# Altium schematic sheet ZYNQ_Core.SchDoc(ZYNQ_Core)
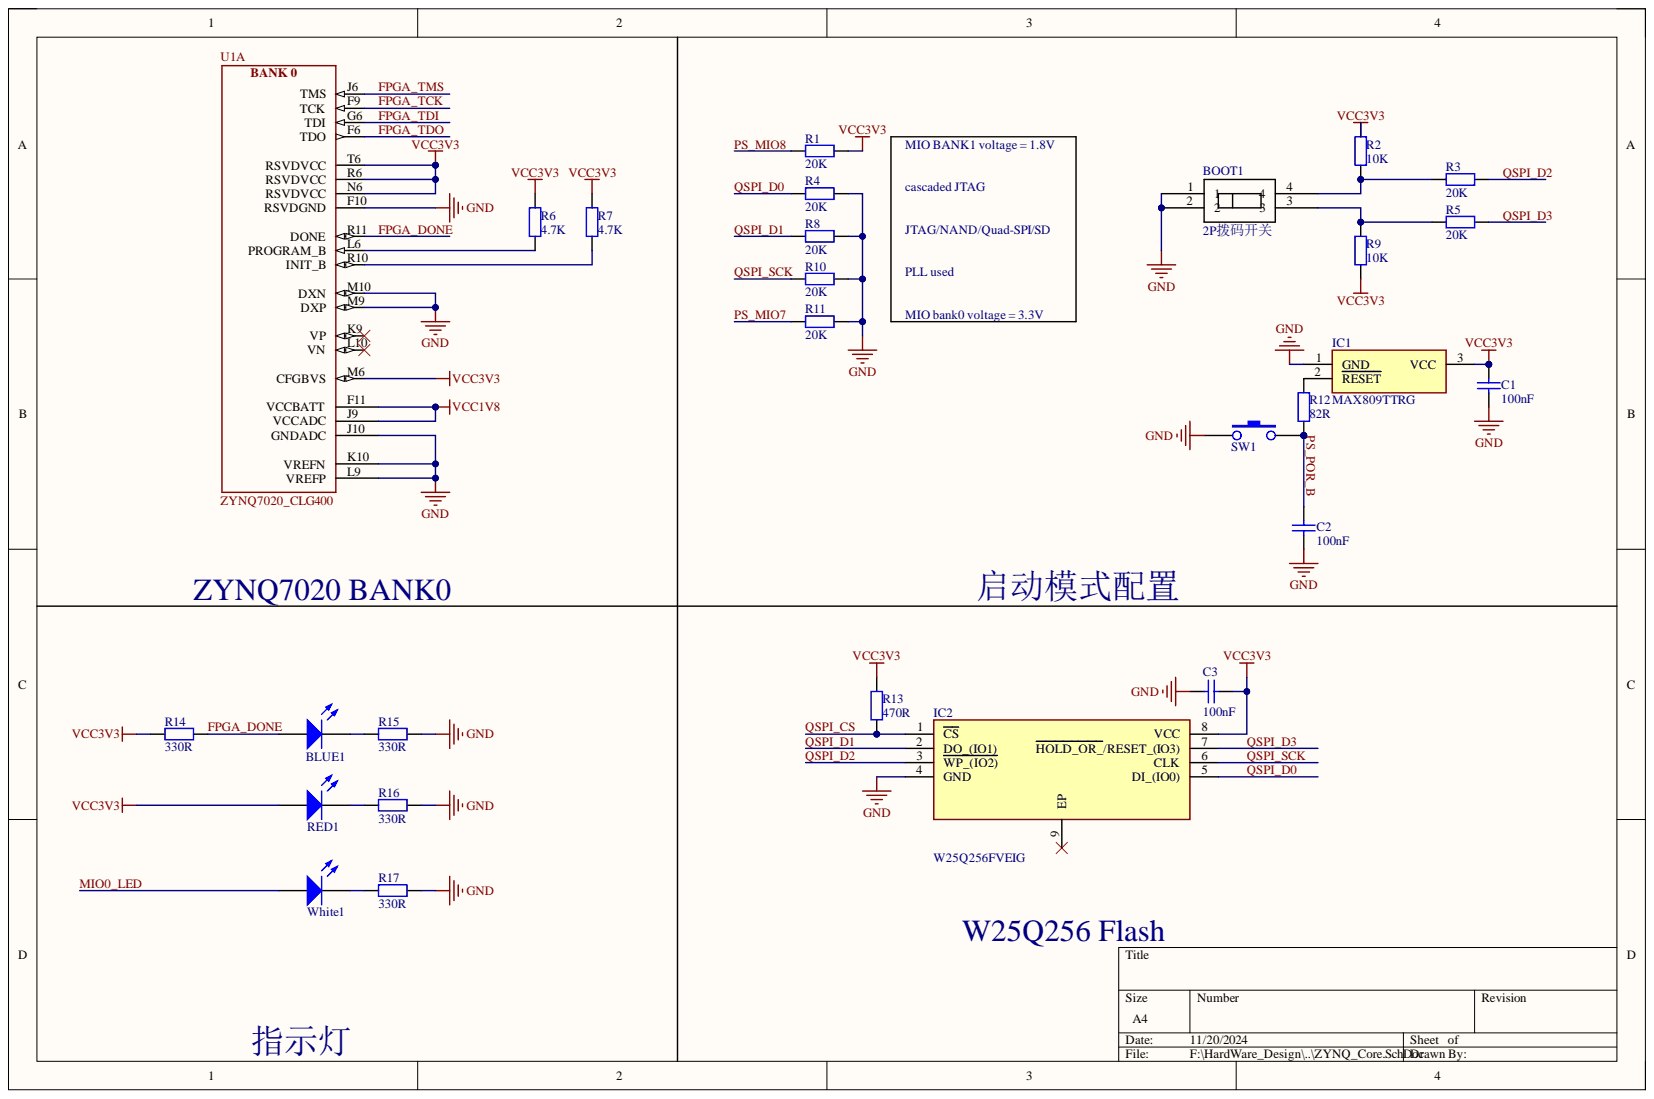
[diagram p0: ZYNQ_Core.SchDoc]

## Parts
BLUE1  LED  [LED 0402 B]  (value not drawn)
BOOT1  2P拨码开关  [CSW1.27 SMD-2P]
C1  100nF  [C 0402_L]
C2  100nF  [C 0402_L]
C3  100nF  [C 0402_L]
IC1  MAX809TTRG  [SOT96P237X111-3N]
IC2  W25Q256FVEIG  [SON127P800X600X80-9N-D]
R1  20K  [R 0402_L]
R2  10K  [R 0402_L]
R3  20K  [R 0402_L]
R4  20K  [R 0402_L]
R5  20K  [R 0402_L]
R6  4.7K  [R 0402_L]
R7  4.7K  [R 0402_L]
R8  20K  [R 0402_L]
R9  10K  [R 0402_L]
R10  20K  [R 0402_L]
R11  20K  [R 0402_L]
R12  82R  [R 0402_L]
R13  470R  [R 0402_L]
R14  330R  [R 0402_L]
R15  330R  [R 0402_L]
R16  330R  [R 0402_L]
R17  330R  [R 0402_L]
RED1  LED  [LED 0402 R]  (value not drawn)
SW1  按键  [SWT3*4]  (value not drawn)
U1  ZYNQ7020_CLG400  [BGA400C80P20X20_1700X1700X160]  (part A)
White1  LED  [LED 0402 G]  (value not drawn)

## Nets
GND: BOOT1.1 BOOT1.2 C1.1 C2.1 C3.2 IC1.1 IC2.4(GND) R4.2 R8.2 R10.2 R11.2 R15.1 R16.1 R17.1 SW1.1 U1.F10(RSVDGND) U1.J10(GNDADC) U1.K10(VREFN) U1.L9(VREFP) U1.M9(DXP) U1.M10(DXN)
VCC1V8: U1.F11(VCCBATT) U1.J9(VCCADC)
VCC3V3: C1.2 C3.1 IC1.3 IC2.8(VCC) R1.2 R2.2 R6.2 R7.2 R9.1 R13.1 R14.1 RED1.1 U1.M6(CFGBVS) U1.N6(RSVDVCC) U1.R6(RSVDVCC) U1.T6(RSVDVCC)
FPGA_DONE: BLUE1.1 R14.2 U1.R11(DONE)
FPGA_TCK: U1.F9(TCK)
FPGA_TDI: U1.G6(TDI)
FPGA_TDO: U1.F6(TDO)
FPGA_TMS: U1.J6(TMS)
MIO0_LED: White1.1
PS_MIO7: R11.1
PS_MIO8: R1.1
PS_POR_B: C2.2 R12.2 SW1.2
QSPI_CS: IC2.1(CS) R13.2
QSPI_D0: IC2.5(DI_(IO0)) R4.1
QSPI_D1: IC2.2(DO_(IO1)) R8.1
QSPI_D2: IC2.3(WP_(IO2)) R3.1
QSPI_D3: IC2.7(HOLD_OR_/RESET_(IO3)) R5.1
QSPI_SCK: IC2.6(CLK) R10.1
NetBLUE1_2: BLUE1.2 R15.2
NetBOOT1_3: BOOT1.3 R5.2 R9.2
NetBOOT1_4: BOOT1.4 R2.1 R3.2
NetIC1_2: IC1.2 R12.1
NetR6_1: R6.1 U1.L6(PROGRAM_B)
NetR7_1: R7.1 U1.R10(INIT_B)
NetR16_2: R16.2 RED1.2
NetR17_2: R17.2 White1.2

Not connected: IC2 9(EP); U1 K9(VP) L10(VN)

## Library data of the parts
C1-C3 = Cap1: Component Kind: Standard; value: 100nF; Library Name: 零散元件库2.SCHLIB
IC1 = MAX809TTRG: Integrated Circuit; Manufacturer_Name: onsemi; Arrow Part Number: MAX809TTRG; Arrow Price/Stock: https://www.arrow.com/en/products/max809ttrg/on-semiconductor; Component Kind: Standard; Datasheet Link: http://www.onsemi.com/pub/Collateral/MAX809S-D.PDF; Height: 1.11mm; Library Name: SamacSys.SchLib; Manufacturer_Part_Number: MAX809TTRG; Mouser Part Number: 863-MAX809TTRG; Mouser Price/Stock: https://www.mouser.co.uk/ProductDetail/onsemi/MAX809TTRG?qs=3JMERSakebpLWYPNGFPMSQ%3D%3D
IC2 = W25Q256FVEIG: Integrated Circuit; Manufacturer_Name: Winbond; Arrow Part Number: W25Q256FVEIG; Arrow Price/Stock: https://www.arrow.com/en/products/w25q256fveig/winbond-electronics; Component Kind: Standard; Datasheet Link: https://www.winbond.com/resource-files/w25q256fv%20revi%2002262016%20kms.pdf; Height: 0.8mm; Library Name: SamacSys.SchLib; Manufacturer_Part_Number: W25Q256FVEIG; Mouser Part Number: N/A; Mouser Price/Stock: https://www.mouser.co.uk/ProductDetail/Winbond/W25Q256FVEIG?qs=rkhjVJ6%2F3ELJv0ZVUL2rAg%3D%3D
R1-R11 R13-R17 = Res: Component Kind: Standard
  R1 R3-R5 R8 R10 R11: value: 20K
  R2 R9: value: 10K
  R6 R7: value: 4.7K
  R13: value: 470R
  R14-R17: value: 330R
  R1 R4 R8 R10 R11 R13: Library Name: 零散元件库2.SCHLIB
  R2 R3 R5-R7 R9 R14-R17: Library Name: 零散元件-V1.1.SCHLIB
R12 = Res_1: Component Kind: Standard; value: 82R; Library Name: 零散元件库2.SCHLIB
U1 = XC7Z020: Zynq 7000 FPGA 20 Die, in a CSG 484 Package; Manufacturer: Xilinx; Component Kind: Standard; Library Name: Miscellaneous Devices.IntLib
BLUE1 RED1 White1 = LED: Component Kind: Standard; Library Name: 零散元件-V1.1.SCHLIB
BOOT1 = 2P拨码开关: Component Kind: Standard; Library Name: ZYNQ_开发板.IntLib
SW1 = 按键: Component Kind: Standard; Library Name: 零散元件-V1.1.SCHLIB
